# Altium schematic sheet Power.SchDoc
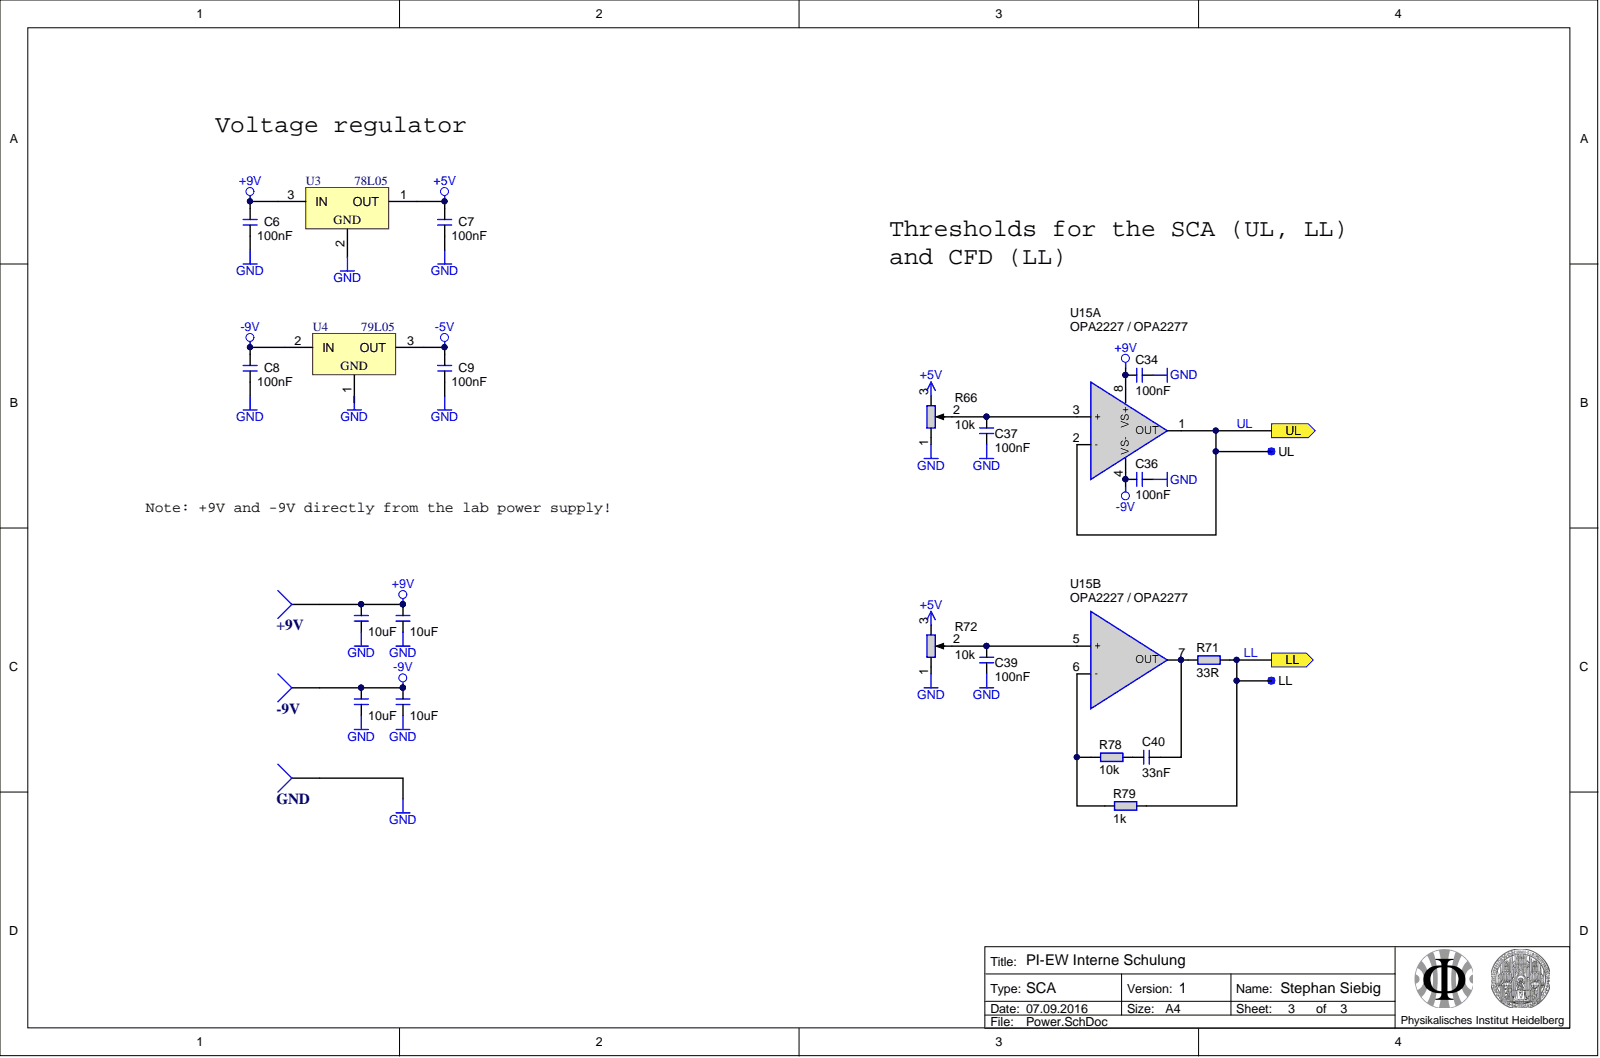
[diagram p0: Power.SchDoc]

## Parts
C6  value 100nF  [0805SMD]
C7  value 100nF  [0805SMD]
C8  value 100nF  [0805SMD]
C9  value 100nF  [0805SMD]
C34  value 100nF  [0805SMD]
C36  value 100nF  [0805SMD]
C37  value 100nF  [0805SMD]
C39  value 100nF  [0805SMD]
C40  value 33nF  [0805SMD]
LL  PAD  [PAD-2MM_1MM]  (value not drawn)  (no BOM)
R66  value 10k  [PO-3299W]  (hidden comment: 3006P-1-XXX)  (no BOM)
R71  value 33R  [R-0603SMD]
R72  value 10k  [PO-3299W]  (hidden comment: 3006P-1-XXX)  (no BOM)
R78  value 10k  [R-0603SMD]
R79  value 1k  [R-0603SMD]
U3  78L05  [LP03]
U4  79L05  [LP03]
U15  OPA2227 / OPA2277  [DIP-8]  (parts A,B)
UL  PAD  [PAD-2MM_1MM]  (value not drawn)  (no BOM)
  value 10uF  [0805SMD]  (no BOM)
  value 10uF  [0805SMD]  (no BOM)
  value 10uF  [0805SMD]  (no BOM)
  value 10uF  [0805SMD]  (no BOM)
  GND  [PIN1]  (no BOM)
  -9V  [PIN1]  (no BOM)
  +9V  [PIN1]  (no BOM)

## Ports
LL, UL

## Nets
+5V: C7.1 R66.3 R72.3 U3.1
+9V: C6.1 C34.1 U3.3 U15.8(VS+) .1
-5V: C9.1 U4.3
-9V: C8.1 C36.1 U4.2 U15.4(VS-) .1
GND: C6.2 C7.2 C8.2 C9.2 C34.2 C36.2 C37.2 C39.2 R66.1 R72.1 U3.2 U4.1 .1 .2
LL: LL.1 R71.1 R79.2 ; port LL
UL: U15.1(OUT) U15.2(-) UL.1 ; port UL
NetC37_1: C37.1 R66.2 U15.3(+)
NetC39_1: C39.1 R72.2 U15.5(+)
NetC40_1: C40.1 R78.2
NetC40_2: C40.2 R71.2 U15.7(OUT)
NetR78_1: R78.1 R79.1 U15.6(-)

## Library data of the parts
C6-C9 C34 C36 C37 C39 = CK-SMD: Keramikkondensator; PART NUMBER: 1612; Component Kind: Standard; Library Name: EW.SchLib
C40 = CK-SMD: Keramikkondensator; PART NUMBER: 1794; Component Kind: Standard; Library Name: EW.SchLib
LL UL = PAD_1: Description: PAD; Library Name: EW.SchLib
R66 R72 = PO-3006P: Potentiometer; PART NUMBER: 2016; PART TYPE: 3006P-1-XXX; Library Name: EW.SchLib
R71 R79 = R-SMD: Widerstand; Component Kind: Standard; Library Name: EW.SchLib
R78 = R-SMD: Widerstand; PART NUMBER: 6516; Component Kind: Standard; Library Name: EW.SchLib
U3 = µA78L05ACLP: Positive-Voltage Regulator; PART NUMBER: 1765; Code_JEDEC: TO-226-AA; Component Kind: Standard; ComponentLink1Description: Manufacturer Link; ComponentLink1URL: http://www.ti.com/; ComponentLink2Description: Datasheet; ComponentLink2URL: http://www-s.ti.com/sc/ds/ua78l05a.pdf; DatasheetVersion: Jul-2000; LatestRevisionDate: 06-Jun-2005; LatestRevisionNote: Sch pins 1 & 3 swapped; Library Name: TI Power Mgt Voltage Regulator.IntLib; PackageDescription: TO, Flat Index; 3 In-Line, Axial Leads; Body Dia. 4.8mm; Leads 0.56 x 0.41 mm (max); PackageReference: LP03; PackageVersion: Nov-2001; PART TYPE: 78L05AC; Published: 15-Apr-2002; Publisher: Altium Limited
U4 = MC79L05ACLP: Negative-Voltage Regulator; PART NUMBER: 2233; ComponentAvailability: Obsolete; Code_JEDEC: TO-226-AA; Component Kind: Standard; ComponentLink1Description: Manufacturer Link; ComponentLink1URL: http://www.ti.com/; ComponentLink2Description: Datasheet; ComponentLink2URL: http://www-s.ti.com/sc/ds/mc79l05.pdf; DatasheetVersion: Feb-2000; LatestRevisionDate: 06-Jun-2005; LatestRevisionNote: Sch pins 1 & 3 swapped; Library Name: TI Power Mgt Voltage Regulator.IntLib; PackageDescription: TO, Flat Index; 3 In-Line, Axial Leads; Body Dia. 4.8mm; Leads 0.56 x 0.41 mm (max); PackageReference: LP03; PackageVersion: Nov-2001; PART TYPE: 79L05AC; Published: 15-Apr-2002; Publisher: Altium Limited
U15 = OP-STANDARD_2CH_8P: Operationsverstärker 2-Fach; Component Kind: Standard; PART TYPE: OPA2227 / OPA2277; Library Name: EW.SchLib
    = CK-SMD: Keramikkondensator; PART NUMBER: 1797; Library Name: EW.SchLib
   = Socket: Description: Socket; LatestRevisionDate: 17-Jul-2002; LatestRevisionNote: Re-released for DXP Platform.; Library Name: Miscellaneous Connectors.IntLib; Signal Integrity: Connector; Publisher: Altium Limited
